# Altium schematic sheet Photo.SchDoc(Photo)
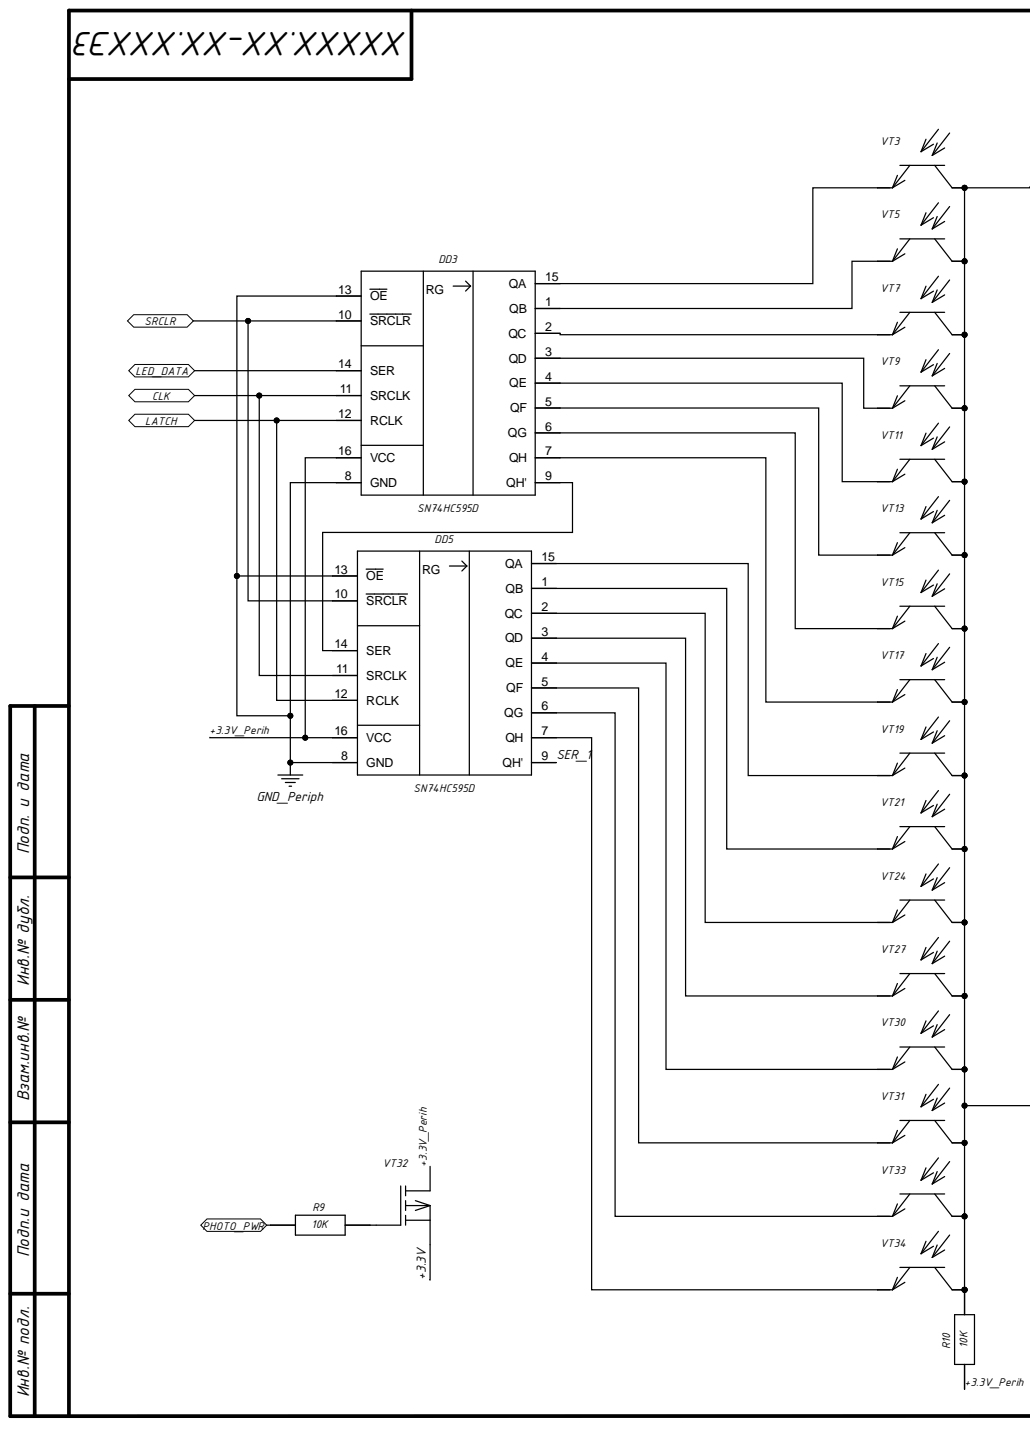
[diagram p0: Photo.SchDoc, left]
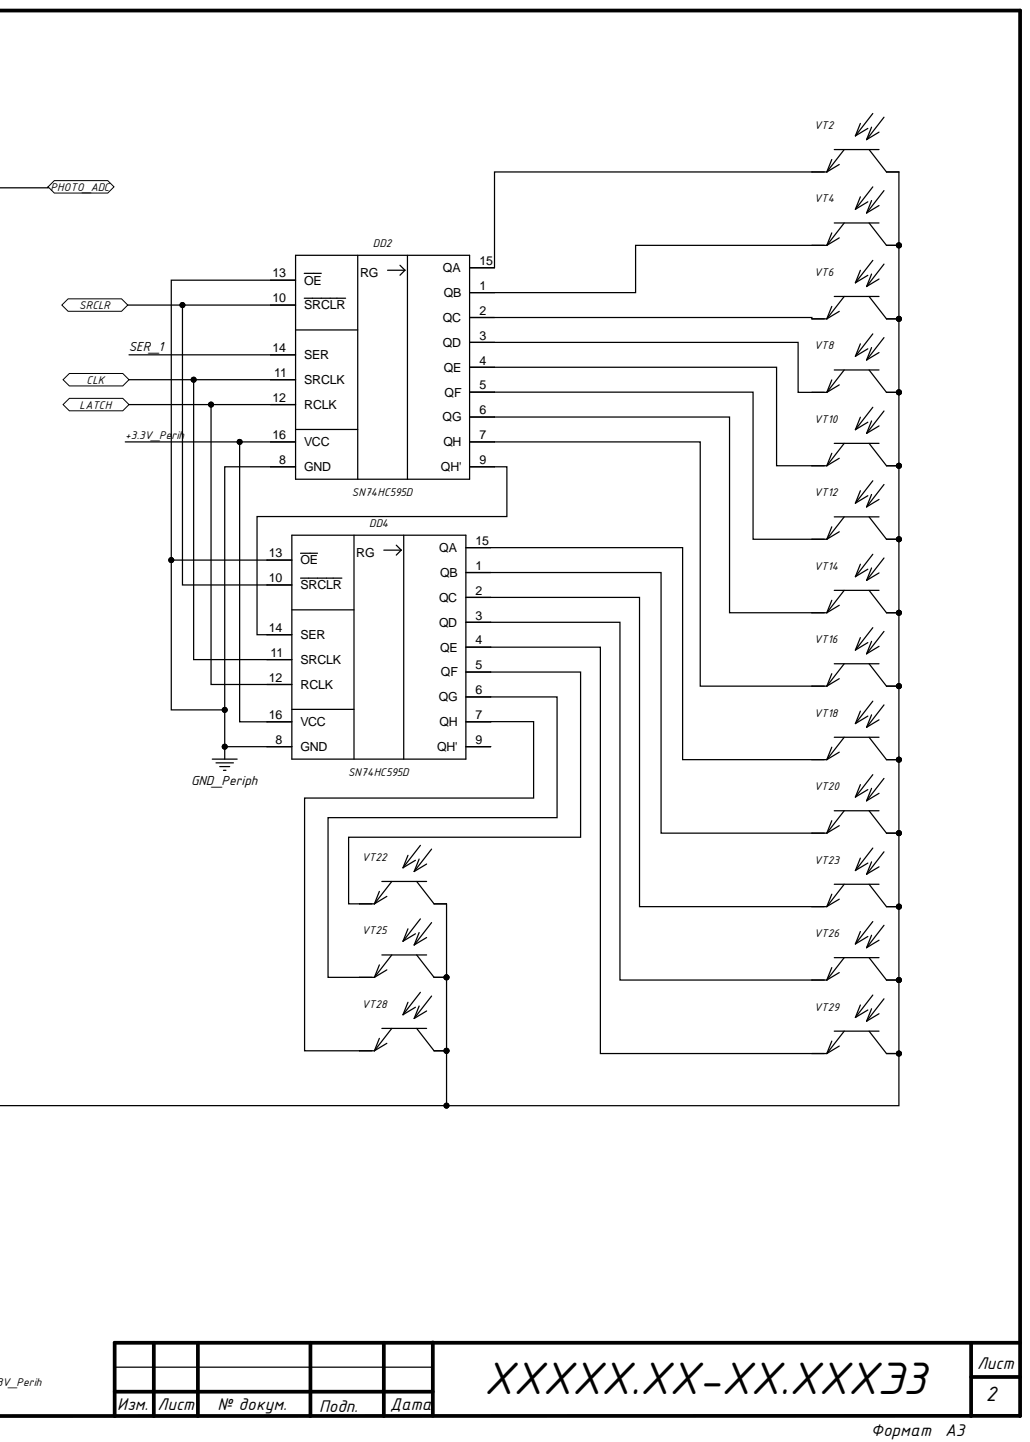
[diagram p1: Photo.SchDoc, right]

## Parts
DD2  SN74HC595D  [SO16_HC]
DD3  SN74HC595D  [SO16_HC]
DD4  SN74HC595D  [SO16_HC]
DD5  SN74HC595D  [SO16_HC]
R9  value 10K  [R_SMD0603]  (hidden comment: R0603)
R10  value 10K  [R_SMD0603]  (hidden comment: R0603)
VT2  KP-3216P3C  [1206_PHOTO]  (value not drawn)
VT3  KP-3216P3C  [1206_PHOTO]  (value not drawn)
VT4  KP-3216P3C  [1206_PHOTO]  (value not drawn)
VT5  KP-3216P3C  [1206_PHOTO]  (value not drawn)
VT6  KP-3216P3C  [1206_PHOTO]  (value not drawn)
VT7  KP-3216P3C  [1206_PHOTO]  (value not drawn)
VT8  KP-3216P3C  [1206_PHOTO]  (value not drawn)
VT9  KP-3216P3C  [1206_PHOTO]  (value not drawn)
VT10  KP-3216P3C  [1206_PHOTO]  (value not drawn)
VT11  KP-3216P3C  [1206_PHOTO]  (value not drawn)
VT12  KP-3216P3C  [1206_PHOTO]  (value not drawn)
VT13  KP-3216P3C  [1206_PHOTO]  (value not drawn)
VT14  KP-3216P3C  [1206_PHOTO]  (value not drawn)
VT15  KP-3216P3C  [1206_PHOTO]  (value not drawn)
VT16  KP-3216P3C  [1206_PHOTO]  (value not drawn)
VT17  KP-3216P3C  [1206_PHOTO]  (value not drawn)
VT18  KP-3216P3C  [1206_PHOTO]  (value not drawn)
VT19  KP-3216P3C  [1206_PHOTO]  (value not drawn)
VT20  KP-3216P3C  [1206_PHOTO]  (value not drawn)
VT21  KP-3216P3C  [1206_PHOTO]  (value not drawn)
VT22  KP-3216P3C  [1206_PHOTO]  (value not drawn)
VT23  KP-3216P3C  [1206_PHOTO]  (value not drawn)
VT24  KP-3216P3C  [1206_PHOTO]  (value not drawn)
VT25  KP-3216P3C  [1206_PHOTO]  (value not drawn)
VT26  KP-3216P3C  [1206_PHOTO]  (value not drawn)
VT27  KP-3216P3C  [1206_PHOTO]  (value not drawn)
VT28  KP-3216P3C  [1206_PHOTO]  (value not drawn)
VT29  KP-3216P3C  [1206_PHOTO]  (value not drawn)
VT30  KP-3216P3C  [1206_PHOTO]  (value not drawn)
VT31  KP-3216P3C  [1206_PHOTO]  (value not drawn)
VT32  IRLML2244TRPBF  [SOT-23]  (value not drawn)
VT33  KP-3216P3C  [1206_PHOTO]  (value not drawn)
VT34  KP-3216P3C  [1206_PHOTO]  (value not drawn)

## Ports
CLK, LATCH, LED_DATA, PHOTO_ADC, PHOTO_PWR, SRCLR

## Nets
GND_Periph: DD2.8(GND) DD2.13(OE) DD3.8(GND) DD3.13(OE) DD4.8(GND) DD4.13(OE) DD5.8(GND) DD5.13(OE)
+3.3V: VT32.2
+3.3V_Perih: DD2.16(VCC) DD3.16(VCC) DD4.16(VCC) DD5.16(VCC) R10.1 VT32.3
CLK: DD2.11(SRCLK) DD3.11(SRCLK) DD4.11(SRCLK) DD5.11(SRCLK) ; port CLK
LATCH: DD2.12(RCLK) DD3.12(RCLK) DD4.12(RCLK) DD5.12(RCLK) ; port LATCH
LED_DATA: DD3.14(SER) ; port LED_DATA
PHOTO_ADC: R10.2 VT2.2 VT3.2 VT4.2 VT5.2 VT6.2 VT7.2 VT8.2 VT9.2 VT10.2 VT11.2 VT12.2 VT13.2 VT14.2 VT15.2 VT16.2 VT17.2 VT18.2 VT19.2 VT20.2 VT21.2 VT22.2 VT23.2 VT24.2 VT25.2 VT26.2 VT27.2 VT28.2 VT29.2 VT30.2 VT31.2 VT33.2 VT34.2 ; port PHOTO_ADC
PHOTO_PWR: R9.1 ; port PHOTO_PWR
SER_1: DD2.14(SER) DD5.9(QH')
SRCLR: DD2.10(SRCLR) DD3.10(SRCLR) DD4.10(SRCLR) DD5.10(SRCLR) ; port SRCLR
NetDD2_1: DD2.1(QB) VT4.1
NetDD2_2: DD2.2(QC) VT6.1
NetDD2_3: DD2.3(QD) VT8.1
NetDD2_4: DD2.4(QE) VT10.1
NetDD2_5: DD2.5(QF) VT12.1
NetDD2_6: DD2.6(QG) VT14.1
NetDD2_7: DD2.7(QH) VT16.1
NetDD2_9: DD2.9(QH') DD4.14(SER)
NetDD2_15: DD2.15(QA) VT2.1
NetDD3_1: DD3.1(QB) VT5.1
NetDD3_2: DD3.2(QC) VT7.1
NetDD3_3: DD3.3(QD) VT9.1
NetDD3_4: DD3.4(QE) VT11.1
NetDD3_5: DD3.5(QF) VT13.1
NetDD3_6: DD3.6(QG) VT15.1
NetDD3_7: DD3.7(QH) VT17.1
NetDD3_9: DD3.9(QH') DD5.14(SER)
NetDD3_15: DD3.15(QA) VT3.1
NetDD4_1: DD4.1(QB) VT20.1
NetDD4_2: DD4.2(QC) VT23.1
NetDD4_3: DD4.3(QD) VT26.1
NetDD4_4: DD4.4(QE) VT29.1
NetDD4_5: DD4.5(QF) VT22.1
NetDD4_6: DD4.6(QG) VT25.1
NetDD4_7: DD4.7(QH) VT28.1
NetDD4_15: DD4.15(QA) VT18.1
NetDD5_1: DD5.1(QB) VT21.1
NetDD5_2: DD5.2(QC) VT24.1
NetDD5_3: DD5.3(QD) VT27.1
NetDD5_4: DD5.4(QE) VT30.1
NetDD5_5: DD5.5(QF) VT31.1
NetDD5_6: DD5.6(QG) VT33.1
NetDD5_7: DD5.7(QH) VT34.1
NetDD5_15: DD5.15(QA) VT19.1
NetR9_2: R9.2 VT32.1

Not connected: DD4 9(QH')

## Library data of the parts
DD2-DD5 = SN74HC595D: ValueManufacturer: TI; Component Kind: Standard; Datasheet: https://www.ti.com/lit/ds/symlink/sn74hc595.pdf?ts=1615985482518&ref_url=https%253A%252F%252Fwww.ti.com%252Fstore%252Fti%252Fen%252Fp%252Fproduct%252F%253Fp%253DSN74HC595D; Library Name: 74hc.SchLib; ValueManufacturerPartNumber: SN74HC595D
R9 R10 = R0603: ValueManufacturerPartNumber: R0603-0.1W-10K-±5%; Power: 0.1W; Tolerance: ±5%; ValueDescription: Resistor; ValueListComponent: , R0603-0.1W-10K-±5%, (Resistor), "" {: }; Component Kind: Standard; Library Name: resistor.IntLib; ValueSCH: R0603-0.1W-10K-±5%; ValueVPI: , R0603-0.1W-10K-±5%, (Resistor), "" {: }
VT2-VT31 VT33 VT34 = KP-3216P3C: ValueManufacturer: Kingbright; ValueListComponent: , KP-3216P3C, (), "Kingbright" {: }; Component Kind: Standard; Datasheet: https://static.chipdip.ru/lib/195/DOC000195327.pdf; Library Name: transistor.IntLib; ValueManufacturerPartNumber: KP-3216P3C; ValueVPI: , KP-3216P3C, (), "Kingbright" {: }
VT32 = IRLML2244TRPBF: HEXFET Power MOSFET. P- chanel, Vds=-20, Id=4.3A, Pd=1.3W, Rds=54mΩ(Vgs=-4.5V); ValueManufacturer: Infineon; ValueListComponent: Transistor, IRLML2244TRPBF, (), "Infineon" {: }; ValueType: Transistor; Component Kind: Standard; Datasheet: https://www.infineon.com/dgdl/irlml2244pbf.pdf?fileId=5546d462533600a401535664bfff25f0; Library Name: transistor.IntLib; ValueManufacturerPartNumber: IRLML2244TRPBF; ValueSCH: IRLML2244TRPBF
